# Altium schematic sheet 3. ISO-OUT.SchDoc("3. ISO-OUT")
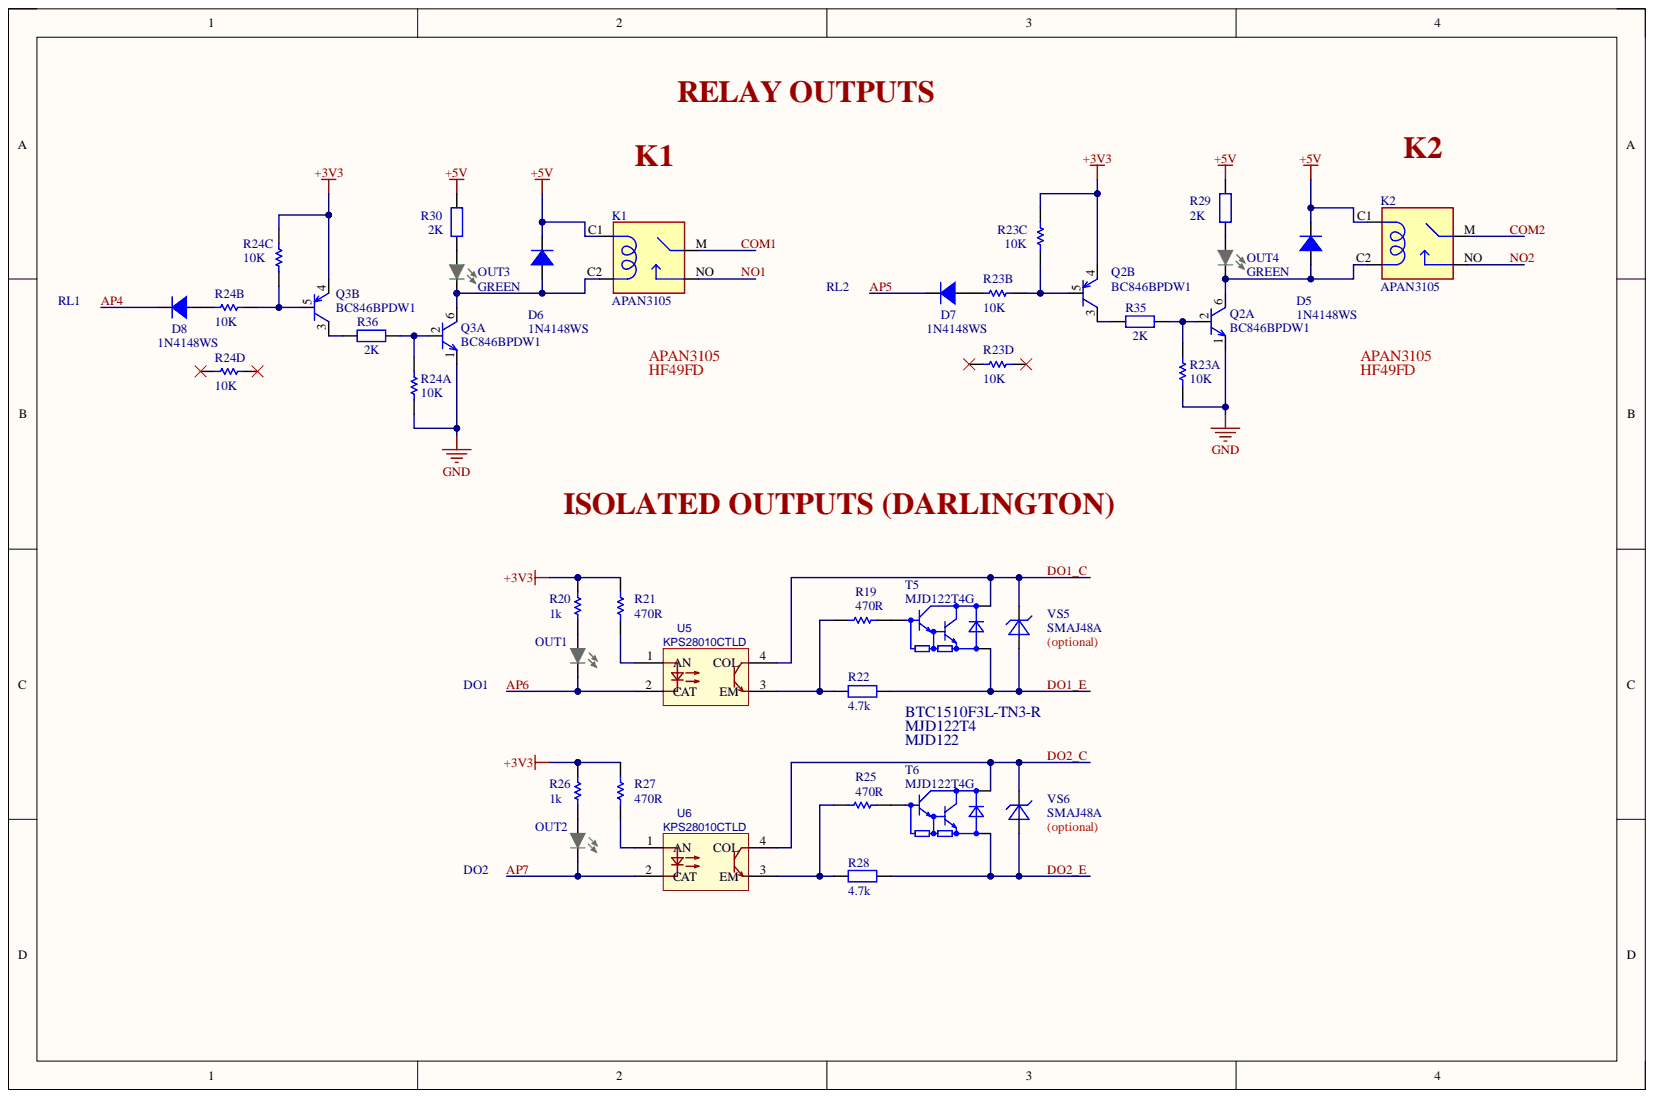
[diagram p0: 3. ISO-OUT.SchDoc]

## Parts
D5  1N4148WS  [SOD323FL]
D6  1N4148WS  [SOD323FL]
D7  1N4148WS  [SOD323FL]
D8  1N4148WS  [SOD323FL]
K1  APAN3105  [RELAY_APAN3105]
K2  APAN3105  [RELAY_APAN3105]
OUT1  GREEN  [LED0603_GREEN]  (value not drawn)
OUT2  GREEN  [LED0603_GREEN]  (value not drawn)
OUT3  GREEN  [LED0603_GREEN]
OUT4  GREEN  [LED0603_GREEN]
Q2  BC846BPDW1  [SOT363]  (parts A,B)
Q3  BC846BPDW1  [SOT363]  (parts A,B)
R19  470R  [RESC1608X50N]
R20  1k  [RESC1608X50N]
R21  470R  [RESC1608X50N]
R22  4.7k  [RESC-0603 (1608) - HD]
R23  10K  [CAC-50P200X100-8M_10K]  (parts A,B,C,D)
R24  10K  [CAC-50P200X100-8M_10K]  (parts A,B,C,D)
R25  470R  [RESC1608X50N]
R26  1k  [RESC1608X50N]
R27  470R  [RESC1608X50N]
R28  4.7k  [RESC-0603 (1608) - HD]
R29  2K  [R0402]
R30  2K  [R0402]
R35  2K  [R0402]
R36  2K  [R0402]
T5  MJD122T4G  [DPAK229P990X238-4N]
T6  MJD122T4G  [DPAK229P990X238-4N]
U5  KPS28010CTLD  [SOP-4_L4.4-W2.8-P1.27-LS7.0-BR]
U6  KPS28010CTLD  [SOP-4_L4.4-W2.8-P1.27-LS7.0-BR]
VS5  SMAJ48A  [SMAJ_UNI realistic]
VS6  SMAJ48A  [SMAJ_UNI realistic]

## Nets
+3V3: Q2.4 Q3.4 R20.2 R21.2 R23.3 R24.3 R26.2 R27.2
+5V: D5.1 D6.1 K1.C1 K2.C1 R29.2 R30.2
GND: Q2.1 Q3.1 R23.1 R24.1
AP4: D8.1
AP5: D7.1
AP6: OUT1.K U5.2
AP7: OUT2.K U6.2
COM1: K1.M
COM2: K2.M
DO1_C: T5.4 U5.4 VS5.2
DO1_E: R22.2 T5.3 VS5.1
DO2_C: T6.4 U6.4 VS6.2
DO2_E: R28.2 T6.3 VS6.1
NO1: K1.NO
NO2: K2.NO
NetD5_2: D5.2 K2.C2 OUT4.K Q2.6
NetD6_2: D6.2 K1.C2 OUT3.K Q3.6
NetD7_2: D7.2 R23.2
NetD8_2: D8.2 R24.2
NetOUT1_A: OUT1.A R20.1
NetOUT2_A: OUT2.A R26.1
NetOUT3_A: OUT3.A R30.1
NetOUT4_A: OUT4.A R29.1
NetQ2_2: Q2.2 R23.8 R35.1
NetQ2_3: Q2.3 R35.2
NetQ2_5: Q2.5 R23.6 R23.7
NetQ3_2: Q3.2 R24.8 R36.1
NetQ3_3: Q3.3 R36.2
NetQ3_5: Q3.5 R24.6 R24.7
NetR19_1: R19.1 T5.1
NetR19_2: R19.2 R22.1 U5.3
NetR21_1: R21.1 U5.1
NetR25_1: R25.1 T6.1
NetR25_2: R25.2 R28.1 U6.3
NetR27_1: R27.1 U6.1

Not connected: R23 4 5; R24 4 5

## Library data of the parts
D5-D8 = DIODE_1N4148WS: DIODE GEN PURP 75V 150MA SOD323F; Manufacturer: ON Semiconductor / Fairchild; Element Configuration: Single; Forward Voltage: 1V; Max Forward Surge Current (Ifsm): 2A; Max Reverse Current: 5uA; Power Dissipation: 200mW; Recovery Time: 4ns; Average Rectified Current: 150mA; Category: Rectifier Diodes; Component Kind: Standard; ComponentLink1Description: https://datasheet.ciiva.com/25968/1n914bws-25968945.pdf?src-supplier=Avnet+Europe; ComponentLink1URL: https://datasheet.ciiva.com/25968/1n914bws-25968945.pdf?src-supplier=Avnet+Europe; ComponentLink2Description: https://datasheet.ciiva.com/30479/0900766b810e1cd3-30479206.pdf?src-supplier=RSComponents; ComponentLink2URL: https://datasheet.ciiva.com/30479/0900766b810e1cd3-30479206.pdf?src-supplier=RSComponents; ComponentLink3Description: https://datasheet.ciiva.com/8300/1n914bws-8300360.pdf?src-supplier=Avnet; ComponentLink3URL: https://datasheet.ciiva.com/8300/1n914bws-8300360.pdf?src-supplier=Avnet; ComponentLink4Description: https://datasheet.ciiva.com/15208/getdatasheetpartid-127819-15208358.pdf?src-supplier=Verical; ComponentLink4URL: https://datasheet.ciiva.com/15208/getdatasheetpartid-127819-15208358.pdf?src-supplier=Verical; ComponentLink5Description: https://datasheet.ciiva.com/910/1n4148ws-910381.pdf?src-supplier=Digi-Key; ComponentLink5URL: https://datasheet.ciiva.com/910/1n4148ws-910381.pdf?src-supplier=Digi-Key; ComponentLink6Description: https://datasheet.ciiva.com/910/1n4148ws-910382.pdf?src-supplier=Digi-Key; ComponentLink6URL: https://datasheet.ciiva.com/910/1n4148ws-910382.pdf?src-supplier=Digi-Key; ComponentLink7Description: https://datasheet.ciiva.com/910/1n4148ws-910380.pdf?src-supplier=Digi-Key; ComponentLink7URL: https://datasheet.ciiva.com/910/1n4148ws-910380.pdf?src-supplier=Digi-Key; Contact Plating: Tin,Matte; Forward Current: 150mA; Height: 1mm; Lead Free: Lead Free; Length: 1.8mm; Manufacturer Part Number: 1N4148WS; Max Operating Temperature: 150°C; Max Repetitive Reverse Voltage (Vrrm): 75V; Max Reverse Voltage (DC): 75V; Min Operating Temperature: -55°C; Mount: Surface Mount; Number of Pins: 2; Package Quantity: 1; Packaging: Tape and Reel; Pricing 1: 1=0.21000, 10=0.19200, 100=0.10460, 500=0.06436, 1000=0.04388 (USD); Radiation Hardening: No; REACH SVHC: No SVHC; Reverse Recovery Time: 4ns; Reverse Voltage: 75V; RoHS: Yes; RoHS Compliant: Yes; Series: -; Stock 1: 680869; Supplier 1: Digi-Key; Supplier Part Number 1: 1N4148WSFSCT-ND; Weight: 0.0276g; Width: 1.35mm; Library Name: Bokra.SCHLIB
K1 K2 = APAN3105: PA-N Relay 5V 1FormA, 5A@250VAC, 30VDC | Panasonic Electronic Components APAN3105; Check_prices: https://www.snapeda.com/parts/APAN3105/Panasonic+Electric+Works/view-part/?ref=eda; Component Kind: Standard; MF: Panasonic; MP: APAN3105; Package: None; SnapEDA_Link: https://www.snapeda.com/parts/APAN3105/Panasonic+Electric+Works/view-part/?ref=snap; Library Name: Bokra.SCHLIB
OUT1-OUT4 = LED0603_GREEN: LED GREEN CLEAR SMD; Manufacturer: XINGLIGHT; Manufacturer Part Number: XL-1608SYGC-06; Dominant Wavelength: 571nm; Forward Current: 30mA; Forward Voltage: 2V; Lens Color: Colorless; Lens Style: Rectangular,Colorless; Luminous Intensity: 35mcd; Max Power Dissipation: 75mW; Number of Elements: 1; Reverse Voltage: 5V; Test Current: 20mA; Viewing Angle: 130°; Wavelength: 574nm; Case Code (Imperial): 0603; Case Code (Metric): 1608; Case/Package: 0603; Category: LEDs; Color: Green; Component Kind: Standard; ComponentLink1Description: https://datasheet.ciiva.com/2109/ltst-c191kgkt-2109973.pdf?src-supplier=Digi-Key; ComponentLink1URL: https://datasheet.ciiva.com/2109/ltst-c191kgkt-2109973.pdf?src-supplier=Digi-Key; Depth: 0.8mm; Height: 0.55mm; Illumination Color: Green; Lead Free: Lead Free; Length: 1.6mm; Lens Transparency: Clear; Library Name: Schematic_Lib.SchLib; Max Operating Temperature: 85°C; Min Operating Temperature: -55°C; Mount: Surface Mount; Number of LEDs: 1; Number of Pins: 2; Package Quantity: 1; Packaging: Tape and Reel; Power Dissipation: 75mW; Power Rating: 75mW; Pricing 1: 1=0.26000, 10=0.14100, 100=0.06560, 500=0.06060, 1000=0.04747, 2000=0.04646 (USD); Radiation Hardening: No; RoHS: Yes; RoHS Compliant: Yes; Stock 1: 910352; Supplier 1: LCSC; Supplier Part Number 1: C965805; Width: 0.8mm
Q2 Q3 = BC846BPDW1_2parts: Dual PNP/NPN transistors SOT363; Component Kind: Standard; PartNumber1: BC846BPDW1; PartNumber2: BC846BPN; Value: BC846BPDW1; Library Name: Bokra.SCHLIB
R19-R21 R25-R27 = Res1: Resistor; Component Kind: Standard; LatestRevisionDate: 17-Jul-2002; LatestRevisionNote: Re-released for DXP Platform.; Library Name: Miscellaneous Devices.IntLib; PackageDescription: Axial Device, Thru-Hole; 2 Leads; 0.3 in Pin Spacing; PackageReference: AXIAL-0.3; Published: 8-Jun-2000; Signal Integrity: Res; Simulation: RESISTOR; Publisher: Altium Limited
  R19 R21 R25 R27: Value: 470R
  R20 R26: Value: 1k
R22 R28 = RC0603FR-074K7L: RES 4.7K OHM 1% 1/10W 0603; MFR: Yageo; Category: RESISTOR; Component Kind: Standard; MPN: RC0603FR-074K7L; Solder: RES-0603; Supplier Part Number 1: C99782; URL: https://www.digikey.com/en/products/detail/yageo/RC0603FR-074K7L/727212; Value: 4.7k; Library Name: Bokra.SCHLIB
R23 R24 = EXB-28V103JX: RES ARRAY 4 RES 10K OHM 0804; Component Kind: Standard; LCSC #: C178757; Value: EXB-28V103JX; Library Name: Bokra.SCHLIB
R29 R30 R35 R36 = 0402WGF2001TCE: -55℃~+155℃ 2kΩ 50V 62.5mW Thick Film Resistor ±1% ±100ppm/℃ 0402 Chip Resistor - Surface Mount ROHS; Component Kind: Standard; LCSC #: C4109; MPN: 0402WGF2001TCE; Value: 2K; Library Name: Bokra.SCHLIB
T5 T6 = MJD122T4G: Bipolar (BJT) Transistor NPN - Darlington 100 V 8 A 4MHz 1.75 W Surface Mount DPAK; MF: ON Semiconductor; Availability: In Stock; Check_prices: https://www.snapeda.com/parts/MJD122T4G/Onsemi/view-part/?ref=eda; Component Kind: Standard; MP: MJD122T4G; Package: DPAK-3 ON Semiconductor; Price: None; Purchase-URL: https://pricing.snapeda.com/search/part/MJD122T4G/?ref=eda; SnapEDA_Link: https://www.snapeda.com/parts/MJD122T4G/Onsemi/view-part/?ref=snap; Value: MJD122T4G; Library Name: IoTextra_Input_2-03.SCHLIB
U5 U6 = KPS28010ATLD: BOM_Manufacturer: COSMO; BOM_Manufacturer Part: KPS28010СTLD; Contributor: LCSC; BOM_JLCPCB Part Class: Extended Part; BOM_Supplier: LCSC; BOM_Supplier Part: C136436; Component Kind: Standard; spicePre: U; spiceSymbolName: KPS28010СTLD; Library Name: IoTextra Octal2.SCHLIB
VS5 VS6 = SMAJxxA: TVS diode 400W Vr=48V SMA; Component Kind: Standard; PartNumber1: SMAJ48A; Value: SMAJ48A; Library Name: IoTextra_Input_2-03.SCHLIB
